# Altium schematic sheet Shield_PCB.SchDoc(Shield_PCB)
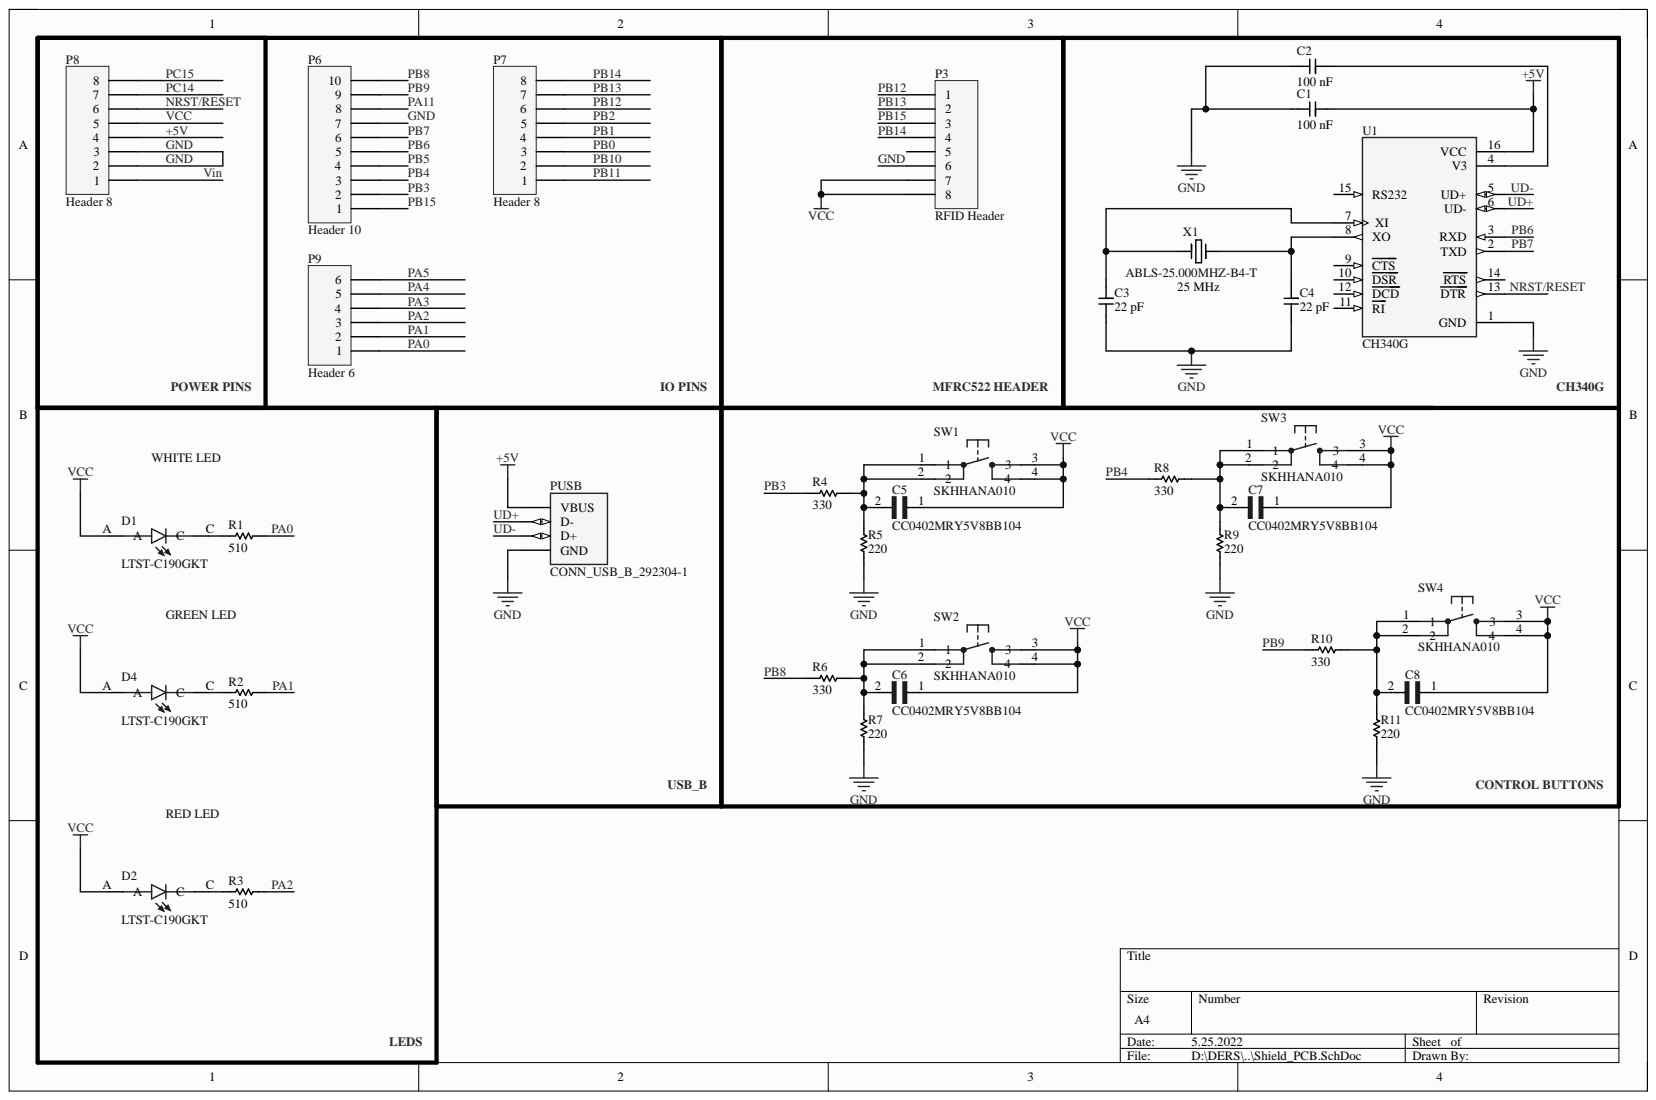
[diagram p0: Shield_PCB.SchDoc]

## Parts
C1  Capacitance: 100 nF  [SAMSUNG_CAP_0603_090]  (hidden comment: CL10B222KB8NNNC)
C2  Capacitance: 100 nF  [SAMSUNG_CAP_0603_090]  (hidden comment: CL10B222KB8NNNC)
C3  Capacitance: 22 pF  [SAMSUNG_CAP_0603_090]  (hidden comment: CL10B222KB8NNNC)
C4  CL10B222KB8NNNC  [SAMSUNG_CAP_0603_090]  (value not drawn)
C5  CC0402MRY5V8BB104  [CAPC1005X55N]
C6  CC0402MRY5V8BB104  [CAPC1005X55N]
C7  CC0402MRY5V8BB104  [CAPC1005X55N]
C8  CC0402MRY5V8BB104  [CAPC1005X55N]
D1  LTST-C190GKT  [LEDM1608X80]
D2  LTST-C190GKT  [LEDM1608X80]
D4  LTST-C190GKT  [LEDM1608X80]
P3  RFID Header  [HDR1X8]
P6  Header 10  [HDR1X10]
P7  Header 8  [HDR1X8]
P8  Header 8  [HDR1X8]
P9  Header 6  [HDR1X6]
PUSB  CONN_USB_B_292304-1  [TE_292304-1]  (value not drawn)
R1  0603SAJ0331T5E  [ROYALOHM_RES_0603]  (value not drawn)
R2  0603SAJ0331T5E  [ROYALOHM_RES_0603]  (value not drawn)
R3  0603SAJ0331T5E  [ROYALOHM_RES_0603]  (value not drawn)
R4  0603SAJ0331T5E  [ROYALOHM_RES_0603]  (value not drawn)
R5  0603SAJ0331T5E  [ROYALOHM_RES_0603]  (value not drawn)
R6  0603SAJ0331T5E  [ROYALOHM_RES_0603]  (value not drawn)
R7  0603SAJ0331T5E  [ROYALOHM_RES_0603]  (value not drawn)
R8  0603SAJ0331T5E  [ROYALOHM_RES_0603]  (value not drawn)
R9  0603SAJ0331T5E  [ROYALOHM_RES_0603]  (value not drawn)
R10  0603SAJ0331T5E  [ROYALOHM_RES_0603]  (value not drawn)
R11  0603SAJ0331T5E  [ROYALOHM_RES_0603]  (value not drawn)
SW1  SKHHANA010  [SW_SKHHANA010]
SW2  SKHHANA010  [SW_SKHHANA010]
SW3  SKHHANA010  [SW_SKHHANA010]
SW4  SKHHANA010  [SW_SKHHANA010]
U1  CH340G  [SOIC127P600X180-16N]
X1  ABLS-25.000MHZ-B4-T  Frequency: 25 MHz  [ABRACON_HC-49/US]

## Nets
+5V: C1.2 P8.4 PUSB.1(VBUS) U1.16(VCC)
GND: C1.1 C2.1 C3.1 C4.1 P3.6 P6.7 P8.2 P8.3 PUSB.4(GND) R5.1 R7.1 R9.1 R11.1 U1.1(GND)
NRST/RESET: P8.6 U1.13(DTR)
PA0: P9.1 R1.2
PA1: P9.2 R2.2
PA2: P9.3 R3.2
PA3: P9.4
PA4: P9.5
PA5: P9.6
PA11: P6.8
PB0: P7.3
PB1: P7.4
PB2: P7.5
PB3: P6.2 R4.1
PB4: P6.3 R8.1
PB5: P6.4
PB6: P6.5 U1.3(RXD)
PB7: P6.6 U1.2(TXD)
PB8: P6.10 R6.1
PB9: P6.9 R10.1
PB10: P7.2
PB11: P7.1
PB12: P3.1 P7.6
PB13: P3.2 P7.7
PB14: P3.4 P7.8
PB15: P3.3 P6.1
PC14: P8.7
PC15: P8.8
UD+: PUSB.2(D-) U1.6(UD-)
UD-: PUSB.3(D+) U1.5(UD+)
VCC: C5.1 C6.1 C7.1 C8.1 D1.A D2.A D4.A P3.7 P3.8 P8.5 SW1.3 SW1.4 SW2.3 SW2.4 SW3.3 SW3.4 SW4.3 SW4.4
Vin: P8.1
NetC2_2: C2.2 U1.4(V3)
NetC3_2: C3.2 U1.7(XI) X1.1
NetC4_2: C4.2 U1.8(XO) X1.2
NetC5_2: C5.2 R4.2 R5.2 SW1.1 SW1.2
NetC6_2: C6.2 R6.2 R7.2 SW2.1 SW2.2
NetC7_2: C7.2 R8.2 R9.2 SW3.1 SW3.2
NetC8_2: C8.2 R10.2 R11.2 SW4.1 SW4.2
NetD1_C: D1.C R1.1
NetD2_C: D2.C R3.1
NetD4_C: D4.C R2.1

Not connected: P3 5; U1 9(CTS) 10(DSR) 11(RI) 12(DCD) 14(RTS) 15(RS232)

## Library data of the parts
C1-C3 = CL10B222KB8NNNC: CAP CER 2200PF 50V X7R 0603; Manufacturer: Samsung Electro-Mechanics; Database Table Name: Ceramic Capacitors; Tolerance: ±10%; Applications: General Purpose; Component Kind: Standard; Digikey Link: https://www.digikey.com/en/products/detail/samsung-electro-mechanics/CL10B222KB8NNNC/3886768?s=N4IgTCBcDaIMIBkCMAGAQmTBpNAOAcoXCALoC%2BQA; Farnell Link: https://tr.farnell.com/samsung-electro-mechanics/cl10b222kb8nnnc/cap-2200pf-50v-mlcc-0603/dp/3013424?st=cl10b222kb8nnnc; Features: -; Height - Seated (Max): -; Lead Spacing: -; Lead Style: -; Library Name: Library.DbLib; Mounting Type: Surface Mount, MLCC; Mouser Link: https://www.mouser.com.tr/ProductDetail/Samsung-Electro-Mechanics/CL10B222KB8NNNC?qs=%2Fha2pyFaduiYAieMNAGY%2Fbybcsm2neKaXkwr9Z%2FZUBvjhuhemCiTrg%3D%3D; Operating Temperature: -55°C ~ 125°C; Ozdisan Link: https://ozdisan.com/pasif-komponentler/kondansatorler/smt-smd-ve-mlcc-kondansatorler/CL10B222KB8NNNC; Package: Tape & Reel (TR); Package / Case: 0603 (1608 Metric); Part Number: CL10B222KB8NNNC; Ratings: -; Series: CL; Size / Dimension: 0.063" L x 0.031" W (1.60mm x 0.80mm); Supplier 1: Digi-Key; Supplier 2: Farnell; Supplier 3: Mouser; Supplier 4: Arrow Electronics; Supplier Part Number 1: 1276-1110-1-ND; Supplier Part Number 2: 3013424; Supplier Part Number 3: 187-CL10B222KB8NNNC; Supplier Part Number 4: CL10B222KB8NNNC; Temperature Coefficient: X7R; Thickness (Max): 0.035" (0.90mm); Voltage - Rated: 50 V
C4 = CL10B222KB8NNNC: CAP CER 2200PF 50V X7R 0603; Manufacturer: Samsung Electro-Mechanics; Capacitance: 22 pF; Database Table Name: Ceramic Capacitors; Tolerance: ±10%; Applications: General Purpose; Component Kind: Standard; Digikey Link: https://www.digikey.com/en/products/detail/samsung-electro-mechanics/CL10B222KB8NNNC/3886768?s=N4IgTCBcDaIMIBkCMAGAQmTBpNAOAcoXCALoC%2BQA; Farnell Link: https://tr.farnell.com/samsung-electro-mechanics/cl10b222kb8nnnc/cap-2200pf-50v-mlcc-0603/dp/3013424?st=cl10b222kb8nnnc; Features: -; Height - Seated (Max): -; Lead Spacing: -; Lead Style: -; Library Name: Library.DbLib; Mounting Type: Surface Mount, MLCC; Mouser Link: https://www.mouser.com.tr/ProductDetail/Samsung-Electro-Mechanics/CL10B222KB8NNNC?qs=%2Fha2pyFaduiYAieMNAGY%2Fbybcsm2neKaXkwr9Z%2FZUBvjhuhemCiTrg%3D%3D; Operating Temperature: -55°C ~ 125°C; Ozdisan Link: https://ozdisan.com/pasif-komponentler/kondansatorler/smt-smd-ve-mlcc-kondansatorler/CL10B222KB8NNNC; Package: Tape & Reel (TR); Package / Case: 0603 (1608 Metric); Part Number: CL10B222KB8NNNC; Ratings: -; Series: CL; Size / Dimension: 0.063" L x 0.031" W (1.60mm x 0.80mm); Supplier 1: Digi-Key; Supplier 2: Farnell; Supplier 3: Mouser; Supplier 4: Arrow Electronics; Supplier Part Number 1: 1276-1110-1-ND; Supplier Part Number 2: 3013424; Supplier Part Number 3: 187-CL10B222KB8NNNC; Supplier Part Number 4: CL10B222KB8NNNC; Temperature Coefficient: X7R; Thickness (Max): 0.035" (0.90mm); Voltage - Rated: 50 V
C5-C8 = CC0402MRY5V8BB104: CAP CER 0.1UF 25V Y5V 0402; Availability: Unavailable; Component Kind: Standard; Library Name: CC0402MRY5V8BB104.IntLib; MP: CC0402MRY5V8BB104; Package: None; Price: None
D1 D2 D4 = LTST-C190GKT: Green 569nm LED Indication - Discrete 2.1V 0603 (1608 Metric); MF: Lite-On Inc.; Component Kind: Standard; Digi-Key_Part_Number: 160-1183-1-ND; DigiKey-Purchase-URL: https://snapeda.com/shop?store=DigiKey&id=46935; Library Name: LTST-C190GKT.IntLib; MP: LTST-C190GKT; Package: 0603 Lite-On; SnapEDA_Link: https://www.snapeda.com/parts/LTST-C190GKT/Lite-On%20Inc./view-part/46935/?ref=snap
P3 P7 P8 = Header 8: Header, 8-Pin; Component Kind: Standard; LatestRevisionDate: 17-Jul-2002; LatestRevisionNote: Re-released for DXP Platform.; Library Name: Miscellaneous Connectors.IntLib; Signal Integrity: Connector; Publisher: Altium Limited
P6 = Header 10: Header, 10-Pin; Component Kind: Standard; LatestRevisionDate: 17-Jul-2002; LatestRevisionNote: Re-released for DXP Platform.; Library Name: Miscellaneous Connectors.IntLib; Signal Integrity: Connector; Publisher: Altium Limited
P9 = Header 6: Header, 6-Pin; Component Kind: Standard; LatestRevisionDate: 17-Jul-2002; LatestRevisionNote: Re-released for DXP Platform.; Library Name: Miscellaneous Connectors.IntLib; Signal Integrity: Connector; Publisher: Altium Limited
PUSB = 292304-1: USB-B _USB TYPE-B_ USB 2.0 Receptacle Connector 4 Position Through Hole, Right Angle; Centerline_Pitch: 2.5 mm[.098 in]; MF: TE Connectivity; Number_of_Positions: 4; Availability: Unavailable; Component Kind: Standard; EU_RoHS_Compliance: Compliant; Library Name: 292304-1.IntLib; MP: 292304-1; Package: None; Price: None; Product_Type: Connector; SnapEDA_Link: https://www.snapeda.com/parts/292304-1/TE%20Connectivity%20AMP%20Connectors/view-part/585812/?ref=snap; TE_purchase_URL: https://www.te.com/usa-en/product-292304-1.html?te_bu=Cor&te_type=disp&te_campaign=seda_glo_cor-seda-global-disp-prtnr-fy19-seda-model-bom-cta_sma-317_1&elqCampaignId=32493
R1-R3 = 0603SAJ0331T5E: RES SMD 510R OHM 5% 1/10W 0603; Manufacturer: Royalohm; Database Table Name: Chip Resistor - Surface Mount; Power (Watts): 0.1 W, 1/10 W; Resistance: 510; Temperature Coefficient: ±100 ppm/°C; Component Kind: Standard; Composition: Thick Film; Digikey Link: -; Failure Rate: -; Farnell Link: -; Features: General Purpose; Height - Seated (Max): 0.022" (0.55mm); Library Name: Library.DbLib; Mouser Link: -; Number of Terminations: 2; Operating Temperature: -55°C ~ 155°C; Ozdisan Link: https://ozdisan.com/pasif-komponentler/direncler/smt-smd-ve-cip-direncler/0603SAJ0331T5E; Package: Tape & Reel (TR); Package / Case: 0603 (1608 Metric); Part Number: 0603SAJ0331T5E; Ratings: -; Series: -; Size / Dimension: 0.063" L x 0.031" W (1.60mm x 0.80mm); Supplier Device Package: 0603; Tolerance: ±5%
R4 R6 R8 R10 = 0603SAJ0331T5E: RES SMD 510R OHM 5% 1/10W 0603; Manufacturer: Royalohm; Database Table Name: Chip Resistor - Surface Mount; Power (Watts): 0.1 W, 1/10 W; Resistance: 330; Temperature Coefficient: ±100 ppm/°C; Component Kind: Standard; Composition: Thick Film; Digikey Link: -; Failure Rate: -; Farnell Link: -; Features: General Purpose; Height - Seated (Max): 0.022" (0.55mm); Library Name: Library.DbLib; Mouser Link: -; Number of Terminations: 2; Operating Temperature: -55°C ~ 155°C; Ozdisan Link: https://ozdisan.com/pasif-komponentler/direncler/smt-smd-ve-cip-direncler/0603SAJ0331T5E; Package: Tape & Reel (TR); Package / Case: 0603 (1608 Metric); Part Number: 0603SAJ0331T5E; Ratings: -; Series: -; Size / Dimension: 0.063" L x 0.031" W (1.60mm x 0.80mm); Supplier Device Package: 0603; Tolerance: ±5%
R5 R7 R9 R11 = 0603SAJ0331T5E: RES SMD 510R OHM 5% 1/10W 0603; Manufacturer: Royalohm; Database Table Name: Chip Resistor - Surface Mount; Power (Watts): 0.1 W, 1/10 W; Resistance: 220; Temperature Coefficient: ±100 ppm/°C; Component Kind: Standard; Composition: Thick Film; Digikey Link: -; Failure Rate: -; Farnell Link: -; Features: General Purpose; Height - Seated (Max): 0.022" (0.55mm); Library Name: Library.DbLib; Mouser Link: -; Number of Terminations: 2; Operating Temperature: -55°C ~ 155°C; Ozdisan Link: https://ozdisan.com/pasif-komponentler/direncler/smt-smd-ve-cip-direncler/0603SAJ0331T5E; Package: Tape & Reel (TR); Package / Case: 0603 (1608 Metric); Part Number: 0603SAJ0331T5E; Ratings: -; Series: -; Size / Dimension: 0.063" L x 0.031" W (1.60mm x 0.80mm); Supplier Device Package: 0603; Tolerance: ±5%
SW1-SW4 = SKHHANA010: Switch Tactile N.O. SPST Round Button PC Pins 0.05A 12VDC 0.98N Thru-Hole Bulk; MF: ALPS; Availability: Unavailable; Component Kind: Standard; Library Name: SKHHANA010.IntLib; MP: SKHHANA010; Package: None; Price: None; SnapEDA_Link: https://www.snapeda.com/parts/SKHHANA010/ALPS/view-part/248805/?ref=snap
U1 = CH340G: USB Interface Integrated Circuit; MF: WCH; Availability: Not in stock; Check_prices: https://www.snapeda.com/parts/Ch340G/WCH/view-part/?ref=eda; Component Kind: Standard; Library Name: CH340G.IntLib; MP: Ch340G; Package: None; Price: None; SnapEDA_Link: https://www.snapeda.com/parts/Ch340G/WCH/view-part/?ref=snap
X1 = ABLS-8.000MHZ-B4-T: CRYSTAL 8.0000MHZ 18PF SMD; Manufacturer: Abracon LLC; Database Table Name: Crystals; ESR (Equivalent Series Resistance): 80 Ohms; Frequency Stability: ±50 ppm; Frequency Tolerance: ±30 ppm; Operating Mode: Fundamental; Component Kind: Standard; Digikey Link: https://www.digikey.com/en/products/detail/abracon-llc/ABLS-8-000MHZ-B4-T/2184155?s=N4IgTCBcDaIIICEAyBlAtADgHQAY8FkAJALTQQBY0AVEAXQF8g; Farnell Link: https://tr.farnell.com/abracon/abls-8-000mhz-b4-t/crystal-8mhz-18pf-hc-49us/dp/2467757?st=abls-8.000mhz-b4-t; Height - Seated (Max): 0.165" (4.20mm); Library Name: Library.DbLib; Load Capacitance: 18 pF; Mounting Type: Surface Mount; Mouser Link: https://www.mouser.com.tr/ProductDetail/ABRACON/ABLS-8000MHZ-B4-T?qs=%2Fha2pyFadug9a307lIAi6Clp1F%252BGEpwfWRmDaTuihuzHm6%252BPPduuWg%3D%3D; Operating Temperature: -20°C ~ 70°C; Ozdisan Link: https://ozdisan.com/pasif-komponentler/kristaller-osilatorler-ve-rezanatorler/kristaller/ABLS-8-000MHZ-B4-T; Package: Tape & Reel (TR); Package / Case: HC-49/US; Part Number: ABLS-8.000MHZ-B4-T; Ratings: -; Series: ABLS; Size / Dimension: 0.449" L x 0.185" W (11.40mm x 4.70mm); Supplier 1: Digi-Key; Supplier 2: Farnell; Supplier 3: Mouser; Supplier 4: Arrow Electronics; Supplier Part Number 1: 535-10212-1-ND; Supplier Part Number 2: 2467757; Supplier Part Number 3: 815-ABLS-8.0M-T; Supplier Part Number 4: ABLS-8.000MHZ-B4-T; Type: MHz Crystal
